# Altium schematic sheet system.SchDoc
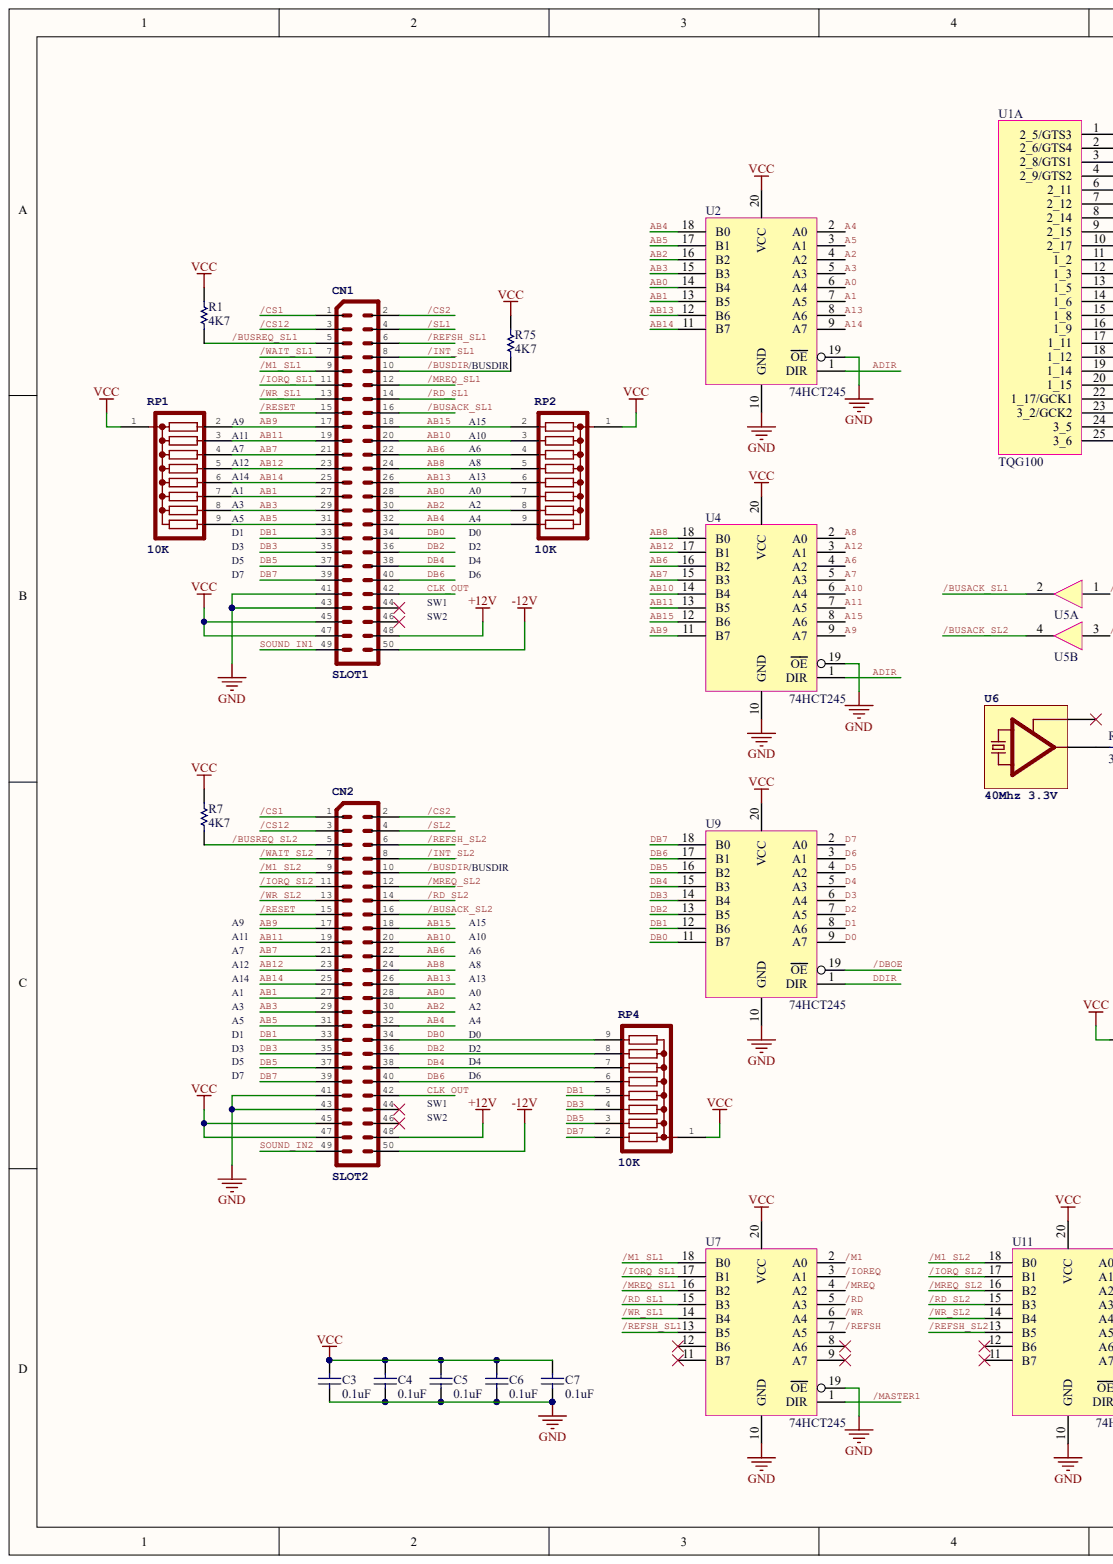
[diagram p0: system.SchDoc, left]
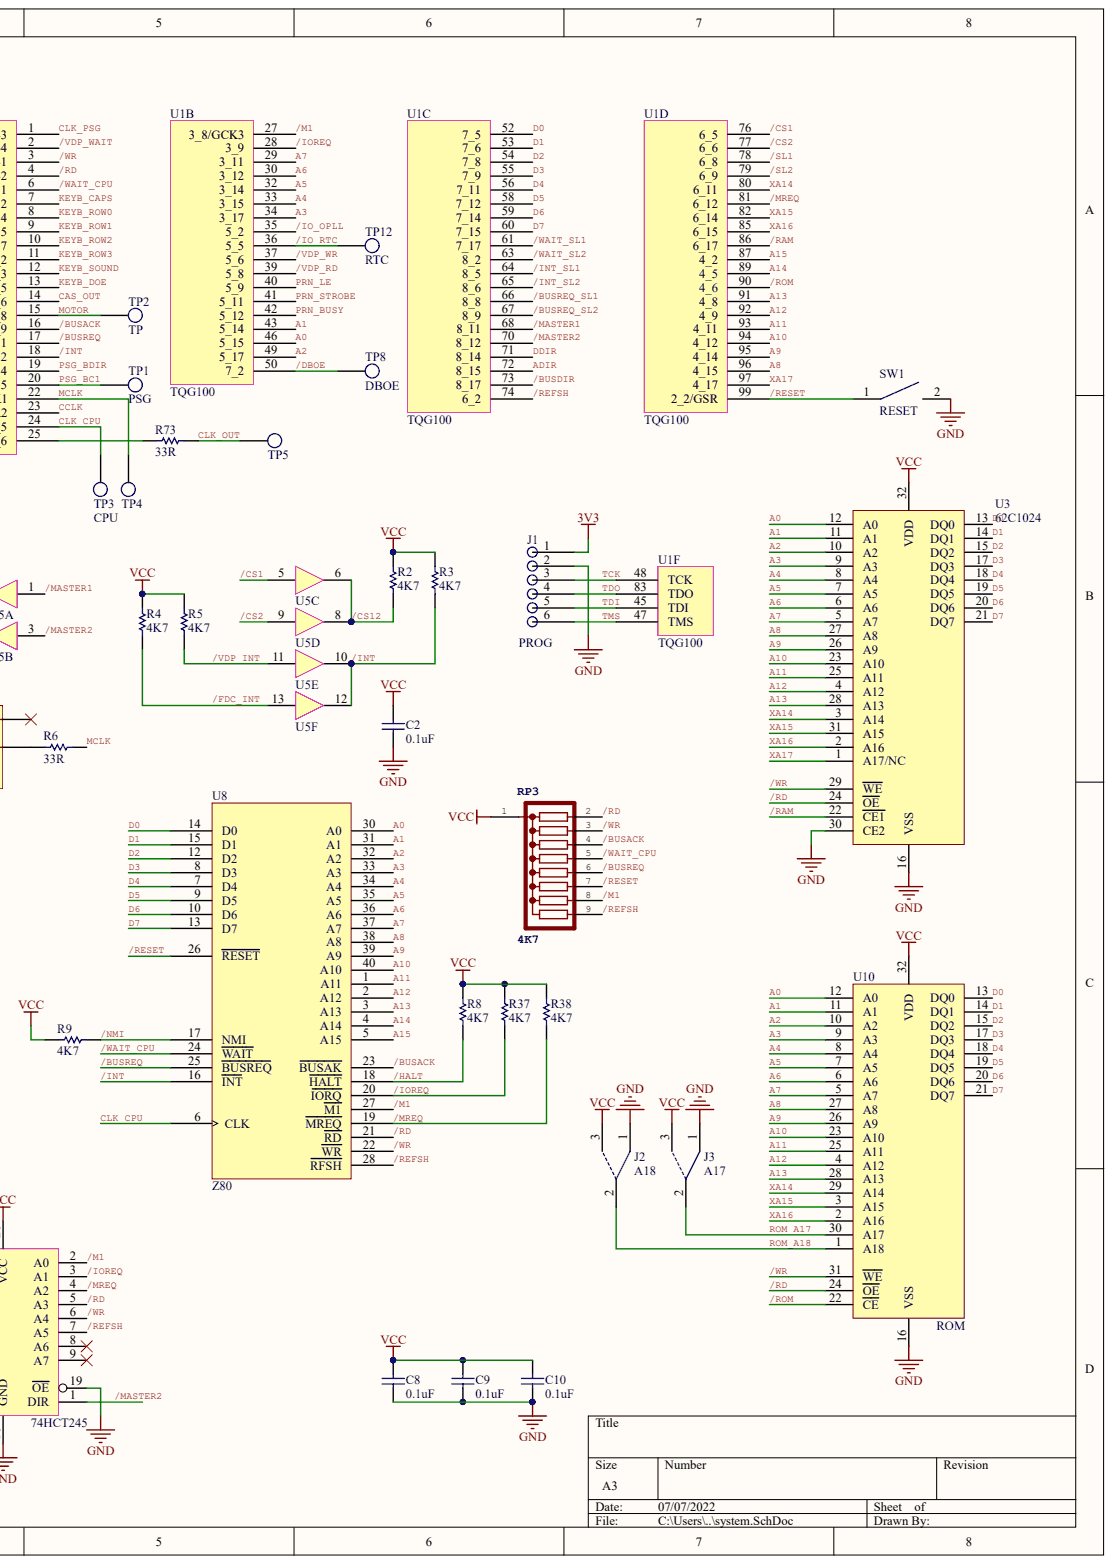
[diagram p1: system.SchDoc, right]

## Parts
C2  0.1uF
C3  0.1uF
C4  0.1uF
C5  0.1uF
C6  0.1uF
C7  0.1uF
C8  0.1uF
C9  0.1uF
C10  0.1uF
CN1  SLOT1
CN2  SLOT2
J1  PROG
J2  A18
J3  A17
R1  4K7
R2  4K7
R3  4K7
R4  4K7
R5  4K7
R6  33R
R7  4K7
R8  4K7
R9  4K7
R37  4K7
R38  4K7
R73  33R
R75  4K7
RP1  10K
RP2  10K
RP3  4K7
RP4  10K
SW1  RESET
TP1  PSG
TP2  TP
TP3  CPU
TP4  TP  (value not drawn)
TP5  TP  (value not drawn)
TP8  DBOE
TP12  RTC
U1  TQG100  (parts A,B,C,D,F)
U2  74HCT245
U3  62C1024
U4  74HCT245
U5  SN74LVC07A  (value not drawn)  (parts A,B,C,D,E,F)
U6  40Mhz 3.3V
U7  74HCT245
U8  Z80
U9  74HCT245
U10  ROM
U11  74HCT245

## Nets
3V3: J1.1 U6.VCC
+12V: CN1.48 CN2.48
-12V: CN1.50 CN2.50
GND: C2.1 C3.1 C4.1 C5.1 C6.1 C7.1 C8.1 C9.1 C10.1 CN1.41 CN1.43 CN2.41 CN2.43 J1.2 J2.1 J3.1 SW1.2 U2.10(GND) U2.19(OE) U3.16(VSS) U3.30(CE2) U4.10(GND) U4.19(OE) U5.7 U6.GND U7.10(GND) U7.19(OE) U8.29 U9.10(GND) U10.16(VSS) U11.10(GND) U11.19(OE)
VCC: C2.2 C3.2 C4.2 C5.2 C6.2 C7.2 C8.2 C9.2 C10.2 CN1.45 CN1.47 CN2.45 CN2.47 J2.3 J3.3 R1.1 R2.1 R3.2 R4.1 R5.1 R7.1 R8.1 R9.1 R37.1 R38.1 R75.1 RP1.1 RP2.1 RP3.1 RP4.1 U2.20(VCC) U3.32(VDD) U4.20(VCC) U5.14 U7.20(VCC) U8.11 U9.20(VCC) U10.32(VDD) U11.20(VCC)
/BUSACK: RP3.4 U1.16(1_9) U8.23(BUSAK)
/BUSACK_SL1: CN1.16 U5.2
/BUSACK_SL2: CN2.16 U5.4
/BUSDIR: CN1.10 CN2.10 R75.2 U1.73(8_17)
/BUSREQ: RP3.6 U1.17(1_11) U8.25(BUSREQ)
/BUSREQ_SL1: CN1.5 R1.2 U1.66(8_8)
/BUSREQ_SL2: CN2.5 R7.2 U1.67(8_9)
/CS1: CN1.1 CN2.1 U1.76(6_5) U5.5
/CS2: CN1.2 CN2.2 U1.77(6_6) U5.9
/CS12: CN1.3 CN2.3 R2.2 U5.6 U5.8
/DBOE: TP8.1 U1.50(7_2) U9.19(OE)
/FDC_INT: R4.2 U5.13
/HALT: R8.2 U8.18(HALT)
/INT: R3.1 U1.18(1_12) U5.10 U5.12 U8.16(INT)
/INT_SL1: CN1.8 U1.64(8_5)
/INT_SL2: CN2.8 U1.65(8_6)
/IO_OPLL: U1.35(5_2)
/IO_RTC: TP12.1 U1.36(5_5)
/IOREQ: R37.2 U1.28(3_9) U7.3(A1) U8.20(IORQ) U11.3(A1)
/IORQ_SL1: CN1.11 U7.17(B1)
/IORQ_SL2: CN2.11 U11.17(B1)
/M1: RP3.8 U1.27(3_8/GCK3) U7.2(A0) U8.27(M1) U11.2(A0)
/M1_SL1: CN1.9 U7.18(B0)
/M1_SL2: CN2.9 U11.18(B0)
/MASTER1: U1.68(8_11) U5.1 U7.1(DIR)
/MASTER2: U1.70(8_12) U5.3 U11.1(DIR)
/MREQ: R38.2 U1.81(6_12) U7.4(A2) U8.19(MREQ) U11.4(A2)
/MREQ_SL1: CN1.12 U7.16(B2)
/MREQ_SL2: CN2.12 U11.16(B2)
/NMI: R9.2 U8.17(NMI)
/RAM: U1.86(6_17) U3.22(CE1)
/RD: RP3.2 U1.4(2_9/GTS2) U3.24(OE) U7.5(A3) U8.21(RD) U10.24(OE) U11.5(A3)
/RD_SL1: CN1.14 U7.15(B3)
/RD_SL2: CN2.14 U11.15(B3)
/REFSH: RP3.9 U1.74(6_2) U7.7(A5) U8.28(RFSH) U11.7(A5)
/REFSH_SL1: CN1.6 U7.13(B5)
/REFSH_SL2: CN2.6 U11.13(B5)
/RESET: CN1.15 CN2.15 RP3.7 SW1.1 U1.99(2_2/GSR) U8.26(RESET)
/ROM: U1.90(4_6) U10.22(CE)
/SL1: CN1.4 U1.78(6_8)
/SL2: CN2.4 U1.79(6_9)
/VDP_INT: R5.2 U5.11
/VDP_RD: U1.39(5_8)
/VDP_WAIT: U1.2(2_6/GTS4)
/VDP_WR: U1.37(5_6)
/WAIT_CPU: RP3.5 U1.6(2_11) U8.24(WAIT)
/WAIT_SL1: CN1.7 U1.61(7_17)
/WAIT_SL2: CN2.7 U1.63(8_2)
/WR: RP3.3 U1.3(2_8/GTS1) U3.29(WE) U7.6(A4) U8.22(WR) U10.31(WE) U11.6(A4)
/WR_SL1: CN1.13 U7.14(B4)
/WR_SL2: CN2.13 U11.14(B4)
A0: U1.46(5_15) U2.6(A4) U3.12(A0) U8.30(A0) U10.12(A0)
A1: U1.43(5_14) U2.7(A5) U3.11(A1) U8.31(A1) U10.11(A1)
A2: U1.49(5_17) U2.4(A2) U3.10(A2) U8.32(A2) U10.10(A2)
A3: U1.34(3_17) U2.5(A3) U3.9(A3) U8.33(A3) U10.9(A3)
A4: U1.33(3_15) U2.2(A0) U3.8(A4) U8.34(A4) U10.8(A4)
A5: U1.32(3_14) U2.3(A1) U3.7(A5) U8.35(A5) U10.7(A5)
A6: U1.30(3_12) U3.6(A6) U4.4(A2) U8.36(A6) U10.6(A6)
A7: U1.29(3_11) U3.5(A7) U4.5(A3) U8.37(A7) U10.5(A7)
A8: U1.96(4_15) U3.27(A8) U4.2(A0) U8.38(A8) U10.27(A8)
A9: U1.95(4_14) U3.26(A9) U4.9(A7) U8.39(A9) U10.26(A9)
A10: U1.94(4_12) U3.23(A10) U4.6(A4) U8.40(A10) U10.23(A10)
A11: U1.93(4_11) U3.25(A11) U4.7(A5) U8.1(A11) U10.25(A11)
A12: U1.92(4_9) U3.4(A12) U4.3(A1) U8.2(A12) U10.4(A12)
A13: U1.91(4_8) U2.8(A6) U3.28(A13) U8.3(A13) U10.28(A13)
A14: U1.89(4_5) U2.9(A7) U8.4(A14)
A15: U1.87(4_2) U4.8(A6) U8.5(A15)
AB0: CN1.28 CN2.28 RP2.7 U2.14(B4)
AB1: CN1.27 CN2.27 RP1.7 U2.13(B5)
AB2: CN1.30 CN2.30 RP2.8 U2.16(B2)
AB3: CN1.29 CN2.29 RP1.8 U2.15(B3)
AB4: CN1.32 CN2.32 RP2.9 U2.18(B0)
AB5: CN1.31 CN2.31 RP1.9 U2.17(B1)
AB6: CN1.22 CN2.22 RP2.4 U4.16(B2)
AB7: CN1.21 CN2.21 RP1.4 U4.15(B3)
AB8: CN1.24 CN2.24 RP2.5 U4.18(B0)
AB9: CN1.17 CN2.17 RP1.2 U4.11(B7)
AB10: CN1.20 CN2.20 RP2.3 U4.14(B4)
AB11: CN1.19 CN2.19 RP1.3 U4.13(B5)
AB12: CN1.23 CN2.23 RP1.5 U4.17(B1)
AB13: CN1.26 CN2.26 RP2.6 U2.12(B6)
AB14: CN1.25 CN2.25 RP1.6 U2.11(B7)
AB15: CN1.18 CN2.18 RP2.2 U4.12(B6)
ADIR: U1.72(8_15) U2.1(DIR) U4.1(DIR)
CAS_OUT: U1.14(1_6)
CCLK: U1.23(3_2/GCK2)
CLK_CPU: TP3.1 U1.24(3_5) U8.6(CLK)
CLK_OUT: CN1.42 CN2.42 R73.1 TP5.1
CLK_PSG: U1.1(2_5/GTS3)
D0: U1.52(7_5) U3.13(DQ0) U8.14(D0) U9.9(A7) U10.13(DQ0)
D1: U1.53(7_6) U3.14(DQ1) U8.15(D1) U9.8(A6) U10.14(DQ1)
D2: U1.54(7_8) U3.15(DQ2) U8.12(D2) U9.7(A5) U10.15(DQ2)
D3: U1.55(7_9) U3.17(DQ3) U8.8(D3) U9.6(A4) U10.17(DQ3)
D4: U1.56(7_11) U3.18(DQ4) U8.7(D4) U9.5(A3) U10.18(DQ4)
D5: U1.58(7_12) U3.19(DQ5) U8.9(D5) U9.4(A2) U10.19(DQ5)
D6: U1.59(7_14) U3.20(DQ6) U8.10(D6) U9.3(A1) U10.20(DQ6)
D7: U1.60(7_15) U3.21(DQ7) U8.13(D7) U9.2(A0) U10.21(DQ7)
DB0: CN1.34 CN2.34 RP4.9 U9.11(B7)
DB1: CN1.33 CN2.33 RP4.5 U9.12(B6)
DB2: CN1.36 CN2.36 RP4.8 U9.13(B5)
DB3: CN1.35 CN2.35 RP4.4 U9.14(B4)
DB4: CN1.38 CN2.38 RP4.7 U9.15(B3)
DB5: CN1.37 CN2.37 RP4.3 U9.16(B2)
DB6: CN1.40 CN2.40 RP4.6 U9.17(B1)
DB7: CN1.39 CN2.39 RP4.2 U9.18(B0)
DDIR: U1.71(8_14) U9.1(DIR)
KEYB_CAPS: U1.7(2_12)
KEYB_DOE: U1.13(1_5)
KEYB_ROW0: U1.8(2_14)
KEYB_ROW1: U1.9(2_15)
KEYB_ROW2: U1.10(2_17)
KEYB_ROW3: U1.11(1_2)
KEYB_SOUND: U1.12(1_3)
MCLK: R6.1 TP4.1 U1.22(1_17/GCK1)
MOTOR: TP2.1 U1.15(1_8)
PRN_BUSY: U1.42(5_12)
PRN_LE: U1.40(5_9)
PRN_STROBE: U1.41(5_11)
PSG_BC1: TP1.1 U1.20(1_15)
PSG_BDIR: U1.19(1_14)
ROM_A17: J3.2 U10.30(A17)
ROM_A18: J2.2 U10.1(A18)
SOUND_IN1: CN1.49
SOUND_IN2: CN2.49
TCK: J1.3 U1.48(TCK)
TDI: J1.5 U1.45(TDI)
TDO: J1.4 U1.83(TDO)
TMS: J1.6 U1.47(TMS)
XA14: U1.80(6_11) U3.3(A14) U10.29(A14)
XA15: U1.82(6_14) U3.31(A15) U10.3(A15)
XA16: U1.85(6_15) U3.2(A16) U10.2(A16)
XA17: U1.97(4_17) U3.1(A17/NC)
NetR6_2: R6.2 U6.OUT
NetR73_2: R73.2 U1.25(3_6)

Not connected: CN1 44 46; CN2 44 46; U6 OE; U7 8(A6) 9(A7) 11(B7) 12(B6); U11 8(A6) 9(A7) 11(B7) 12(B6)

## Library data of the parts
C2-C10 CN1 CN2 J1-J3 R1-R9 R37 R38 R73 R75 RP1-RP4 SW1 TP1-TP5 TP8 TP12 U1-U11 = (no symbol name)
  C2-C10: VALUE: 0.1uF
  CN1: PartNumber: SLOT1
  CN2: PartNumber: SLOT2
  J2: VALUE: A18
  J3: VALUE: A17
  R1-R5 R7-R9 R37 R38 R75 RP3: Value: 4K7
  R6 R73: Value: 33R
  RP1 RP2 RP4: Value: 10K
  SW1: PartNumber: RESET
  TP1: Value: PSG
  TP2 TP4 TP5: Value: TP
  TP3: Value: CPU
  TP8: Value: DBOE
  TP12: Value: RTC
  U1: PartNumber: TQG100
  U2 U4 U7 U9 U11: VALUE: 74HCT245
  U3: PartNumber: 62C1024
  U5: PartNumber: SN74LVC07A
  U6: PartNumber: 40Mhz 3.3V
  U8: PartNumber: Z80
  U10: Value: ROM
